# Altium schematic sheet Eco-Stationnement_Parking_IR1.SchDoc(Eco-Stationnement_Parking_IR1)
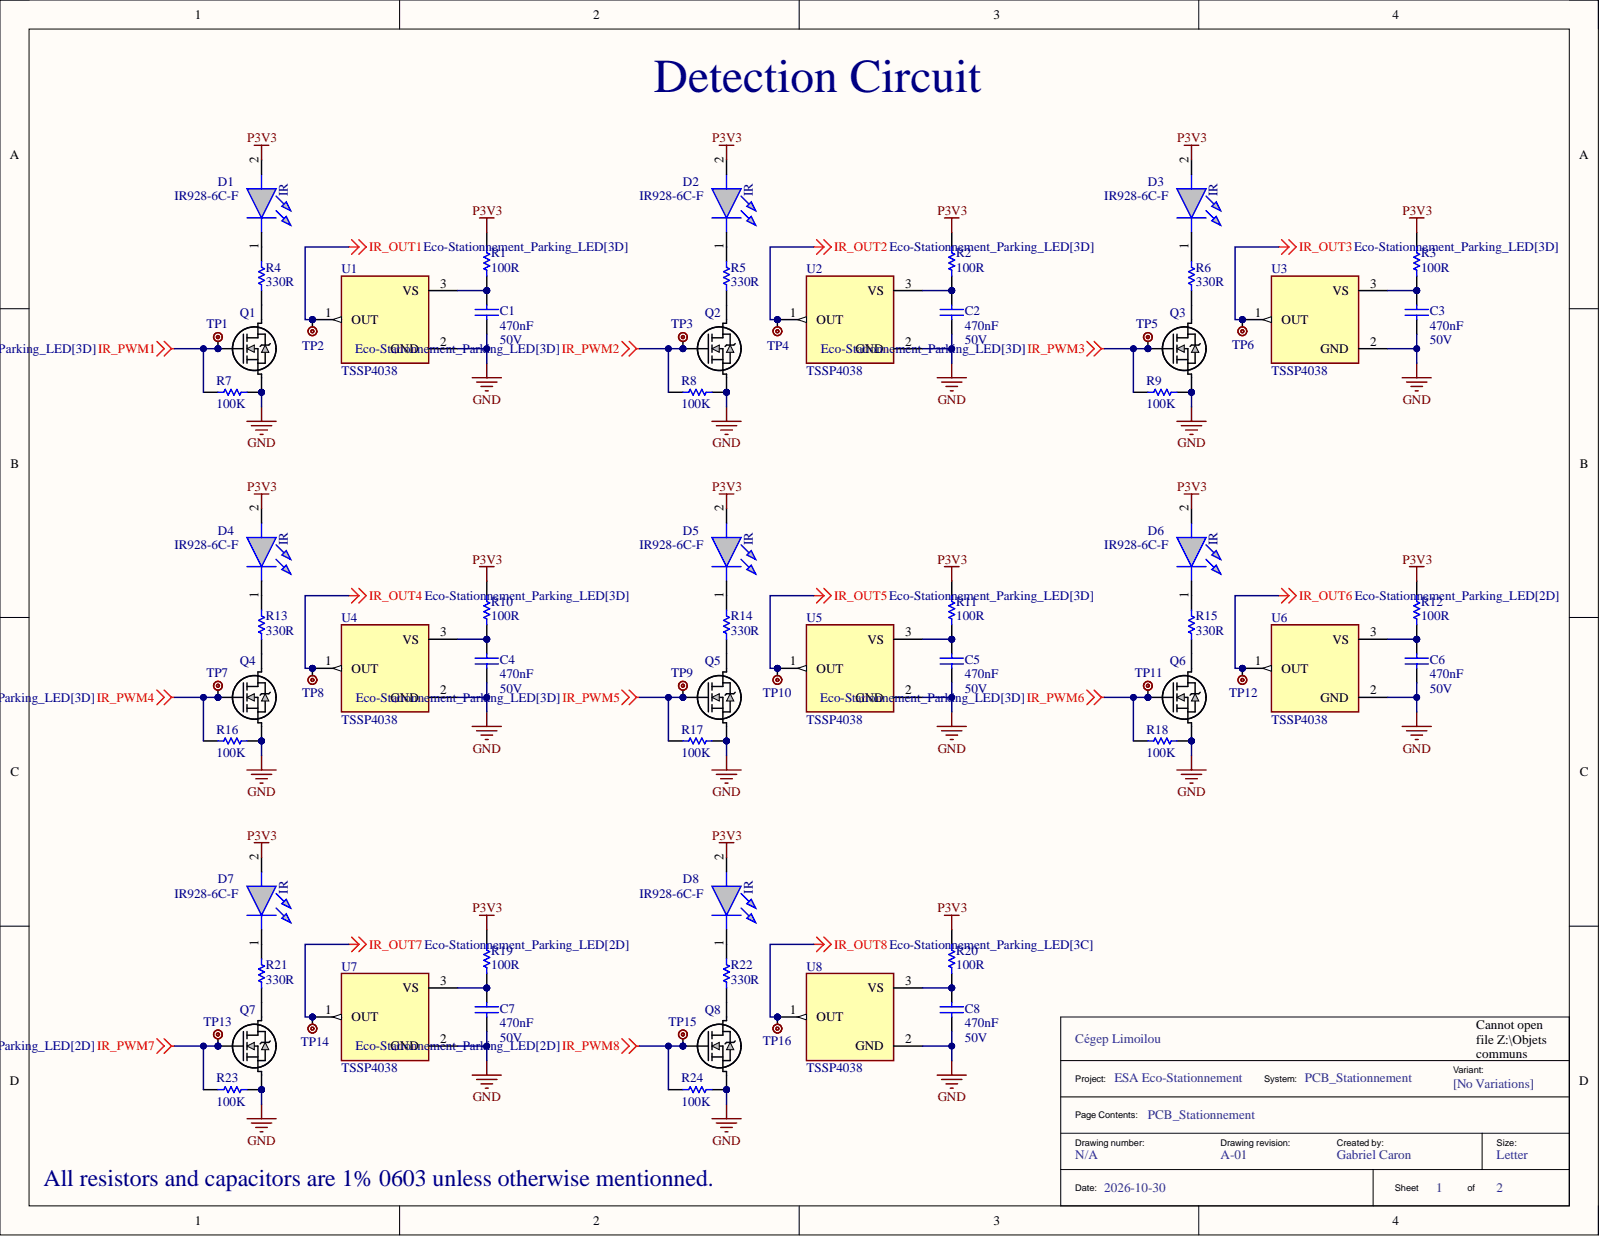
[diagram p0: Eco-Stationnement_Parking_IR1.SchDoc]

## Parts
C1  value 470nF  Voltage: 50V  [C0603]  (hidden comment: C1608X5R1H474K080AB)
C2  value 470nF  Voltage: 50V  [C0603]  (hidden comment: C1608X5R1H474K080AB)
C3  value 470nF  Voltage: 50V  [C0603]  (hidden comment: C1608X5R1H474K080AB)
C4  value 470nF  Voltage: 50V  [C0603]  (hidden comment: C1608X5R1H474K080AB)
C5  value 470nF  Voltage: 50V  [C0603]  (hidden comment: C1608X5R1H474K080AB)
C6  value 470nF  Voltage: 50V  [C0603]  (hidden comment: C1608X5R1H474K080AB)
C7  value 470nF  Voltage: 50V  [C0603]  (hidden comment: C1608X5R1H474K080AB)
C8  value 470nF  Voltage: 50V  [C0603]  (hidden comment: C1608X5R1H474K080AB)
D1  IR928-6C-F  [IR9286CF]
D2  IR928-6C-F  [IR9286CF]
D3  IR928-6C-F  [IR9286CF]
D4  IR928-6C-F  [IR9286CF]
D5  IR928-6C-F  [IR9286CF]
D6  IR928-6C-F  [IR9286CF]
D7  IR928-6C-F  [IR9286CF]
D8  IR928-6C-F  [IR9286CF]
Q1  TRA0001  [TO-236AB_NEX]  (value not drawn)
Q2  TRA0001  [TO-236AB_NEX]  (value not drawn)
Q3  TRA0001  [TO-236AB_NEX]  (value not drawn)
Q4  TRA0001  [TO-236AB_NEX]  (value not drawn)
Q5  TRA0001  [TO-236AB_NEX]  (value not drawn)
Q6  TRA0001  [TO-236AB_NEX]  (value not drawn)
Q7  TRA0001  [TO-236AB_NEX]  (value not drawn)
Q8  TRA0001  [TO-236AB_NEX]  (value not drawn)
R1  value 100R  [R0603]  (hidden comment: AC0603FR-07100RL)
R2  value 100R  [R0603]  (hidden comment: AC0603FR-07100RL)
R3  value 100R  [R0603]  (hidden comment: AC0603FR-07100RL)
R4  value 330R  [R0603]  (hidden comment: RC0603FR-07330RL)
R5  value 330R  [R0603]  (hidden comment: RC0603FR-07330RL)
R6  value 330R  [R0603]  (hidden comment: RC0603FR-07330RL)
R7  value 100K  [R0603]  (hidden comment: RC0603FR-07100KL)
R8  value 100K  [R0603]  (hidden comment: RC0603FR-07100KL)
R9  value 100K  [R0603]  (hidden comment: RC0603FR-07100KL)
R10  value 100R  [R0603]  (hidden comment: AC0603FR-07100RL)
R11  value 100R  [R0603]  (hidden comment: AC0603FR-07100RL)
R12  value 100R  [R0603]  (hidden comment: AC0603FR-07100RL)
R13  value 330R  [R0603]  (hidden comment: RC0603FR-07330RL)
R14  value 330R  [R0603]  (hidden comment: RC0603FR-07330RL)
R15  value 330R  [R0603]  (hidden comment: RC0603FR-07330RL)
R16  value 100K  [R0603]  (hidden comment: RC0603FR-07100KL)
R17  value 100K  [R0603]  (hidden comment: RC0603FR-07100KL)
R18  value 100K  [R0603]  (hidden comment: RC0603FR-07100KL)
R19  value 100R  [R0603]  (hidden comment: AC0603FR-07100RL)
R20  value 100R  [R0603]  (hidden comment: AC0603FR-07100RL)
R21  value 330R  [R0603]  (hidden comment: RC0603FR-07330RL)
R22  value 330R  [R0603]  (hidden comment: RC0603FR-07330RL)
R23  value 100K  [R0603]  (hidden comment: RC0603FR-07100KL)
R24  value 100K  [R0603]  (hidden comment: RC0603FR-07100KL)
TP1  5000  [TP_5000_MIN]  (value not drawn)
TP2  5000  [TP_5000_MIN]  (value not drawn)
TP3  5000  [TP_5000_MIN]  (value not drawn)
TP4  5000  [TP_5000_MIN]  (value not drawn)
TP5  5000  [TP_5000_MIN]  (value not drawn)
TP6  5000  [TP_5000_MIN]  (value not drawn)
TP7  5000  [TP_5000_MIN]  (value not drawn)
TP8  5000  [TP_5000_MIN]  (value not drawn)
TP9  5000  [TP_5000_MIN]  (value not drawn)
TP10  5000  [TP_5000_MIN]  (value not drawn)
TP11  5000  [TP_5000_MIN]  (value not drawn)
TP12  5000  [TP_5000_MIN]  (value not drawn)
TP13  5000  [TP_5000_MIN]  (value not drawn)
TP14  5000  [TP_5000_MIN]  (value not drawn)
TP15  5000  [TP_5000_MIN]  (value not drawn)
TP16  5000  [TP_5000_MIN]  (value not drawn)
U1  TSSP4038  [XDCR_TSSP4038]
U2  TSSP4038  [XDCR_TSSP4038]
U3  TSSP4038  [XDCR_TSSP4038]
U4  TSSP4038  [XDCR_TSSP4038]
U5  TSSP4038  [XDCR_TSSP4038]
U6  TSSP4038  [XDCR_TSSP4038]
U7  TSSP4038  [XDCR_TSSP4038]
U8  TSSP4038  [XDCR_TSSP4038]

## Nets
GND: C1.1 C2.1 C3.1 C4.1 C5.1 C6.1 C7.1 C8.1 Q1.2 Q2.2 Q3.2 Q4.2 Q5.2 Q6.2 Q7.2 Q8.2 R7.2 R8.2 R9.2 R16.2 R17.2 R18.2 R23.2 R24.2 U1.2 U2.2 U3.2 U4.2 U5.2 U6.2 U7.2 U8.2
IR_OUT1: TP2.1 U1.1
IR_OUT2: TP4.1 U2.1
IR_OUT3: TP6.1 U3.1
IR_OUT4: TP8.1 U4.1
IR_OUT5: TP10.1 U5.1
IR_OUT6: TP12.1 U6.1
IR_OUT7: TP14.1 U7.1
IR_OUT8: TP16.1 U8.1
IR_PWM1: Q1.1 R7.1 TP1.1
IR_PWM2: Q2.1 R8.1 TP3.1
IR_PWM3: Q3.1 R9.1 TP5.1
IR_PWM4: Q4.1 R16.1 TP7.1
IR_PWM5: Q5.1 R17.1 TP9.1
IR_PWM6: Q6.1 R18.1 TP11.1
IR_PWM7: Q7.1 R23.1 TP13.1
IR_PWM8: Q8.1 R24.1 TP15.1
P3V3: D1.2 D2.2 D3.2 D4.2 D5.2 D6.2 D7.2 D8.2 R1.2 R2.2 R3.2 R10.2 R11.2 R12.2 R19.2 R20.2
NetC1_2: C1.2 R1.1 U1.3
NetC2_2: C2.2 R2.1 U2.3
NetC3_2: C3.2 R3.1 U3.3
NetC4_2: C4.2 R10.1 U4.3
NetC5_2: C5.2 R11.1 U5.3
NetC6_2: C6.2 R12.1 U6.3
NetC7_2: C7.2 R19.1 U7.3
NetC8_2: C8.2 R20.1 U8.3
NetD1_1: D1.1 R4.2
NetD2_1: D2.1 R5.2
NetD3_1: D3.1 R6.2
NetD4_1: D4.1 R13.2
NetD5_1: D5.1 R14.2
NetD6_1: D6.1 R15.2
NetD7_1: D7.1 R21.2
NetD8_1: D8.1 R22.2
NetQ1_3: Q1.3 R4.1
NetQ2_3: Q2.3 R5.1
NetQ3_3: Q3.3 R6.1
NetQ4_3: Q4.3 R13.1
NetQ5_3: Q5.3 R14.1
NetQ6_3: Q6.3 R15.1
NetQ7_3: Q7.3 R21.1
NetQ8_3: Q8.3 R22.1

## Library data of the parts
C1-C8 = CAP0124: CAP CER 0.47UF 50V X5R 0603; Manufacturer: Walsin Technology Corporation; ID_CEP: MCAP0124; Verif_1: RCA; Component Kind: Standard; Fitted: Fitted; Package: 0603; PN_Manuf: C1608X5R1H474K080AB; Library Name: Eco-Stationnement_Parking.SchLib
D1-D8 = IR928-6C-F: Infrared Emitter 940nm Circular Right Angle 2-Pin Bag; Manufacturer: Everlight; Geometry.Height: 4.7mm; Component Kind: Standard; Datasheet Link: https://www.mouser.fr/ProductDetail/Everlight/IR928-6C-F?qs=8PzhAHr7IdNp8oknw19ZjQ%3D%3D; Fitted: Fitted; Manufacturer_Name: Everlight; Manufacturer_Part_Number: IR928-6C-F; Mouser Part Number: 638-IR9286CF; Mouser Price/Stock: https://www.mouser.co.uk/ProductDetail/Everlight/IR928-6C-F?qs=8PzhAHr7IdNp8oknw19ZjQ%3D%3D; PN_Manuf: IR928-6C-F; Library Name: Eco-Stationnement_Parking.SchLib
Q1-Q8 = TRA0001: MOSFET N-CH 60V 300MA TO236AB; Manufacturer: Nexperia; Manufacturer_Part_Number: 2N7002,215; Verif_1: GC; Component Kind: Standard; Fitted: Fitted; ID_CEP: TRA0001; Manufacturer_Name: Nexperia; PN_Manuf: 2N7002,215; Copyright: Copyright (C) 2025 Ultra Librarian. All rights reserved.; Library Name: Eco-Stationnement_Parking.SchLib
R1-R3 R10-R12 R19 R20 = RES0018: RES 100 OHM 1% 1/10W 0603; Manufacturer: Yageo; ID_CEP: MRES0018; Verif_1: RCA le 2022-03-16; Component Kind: Standard; Fitted: Fitted; Package: 0603; PN_Manuf: AC0603FR-07100RL; Library Name: Eco-Stationnement_Parking.SchLib
R4-R6 R13-R15 R21 R22 = RES0053: RES 330 OHM 1% 1/10W 0603; Manufacturer: YAGEO; ID_CEP: MRES0053; Verif_1: JSMG; Component Kind: Standard; Cost: 0.01; Fitted: Fitted; LatestRevisionDate: 17-Jul-2002; LatestRevisionNote: Re-released for DXP Platform.; Package: 0603; PackageDescription: Axial Device, Thru-Hole; 2 Leads; 0.3 in Pin Spacing; PackageReference: AXIAL-0.3; PN_Manuf: RC0603FR-07330RL; Published: 8-Jun-2000; Library Name: Eco-Stationnement_Parking.SchLib; Publisher: Altium Limited
R7-R9 R16-R18 R23 R24 = RES0003: RES SMD 100K OHM 1% 1/10W 0603; Manufacturer: YAGEO; ID_CEP: MRES0003; Verif_1: JSMG; Component Kind: Standard; Cost: 0.01; Fitted: Fitted; LatestRevisionDate: 17-Jul-2002; LatestRevisionNote: Re-released for DXP Platform.; Package: 0603; PackageDescription: Axial Device, Thru-Hole; 2 Leads; 0.3 in Pin Spacing; PackageReference: AXIAL-0.3; PN_Manuf: RC0603FR-07100KL; Published: 8-Jun-2000; Library Name: Eco-Stationnement_Parking.SchLib; Publisher: Altium Limited
TP1-TP16 = DIV0034: PC TEST POINT MINIATURE RED 1mm; Manufacturer: Keystone Electronics; Fitted: Not Fitted; ID_CEP: MDIV0034; Verif_1: RCA le 2024-03-27; Component Kind: Standard; PN_Manuf: 5000; Library Name: Eco-Stationnement_Parking.SchLib
U1-U8 = TSSP4038: Remote Receiver Sensor, 38.0kHz 1m PCB, Through Hole; Manufacturer: Vishay Semiconductor; Check_prices: https://www.snapeda.com/parts/TSSP4038/Vishay/view-part/?ref=eda; Component Kind: Standard; Fitted: Fitted; MF: Vishay Semiconductor; MP: TSSP4038; Package: None; PN_Manuf: TSSP4038; SnapEDA_Link: https://www.snapeda.com/parts/TSSP4038/Vishay/view-part/?ref=snap; Library Name: Eco-Stationnement_Parking.SchLib
